# Altium schematic sheet AD9288.SchDoc
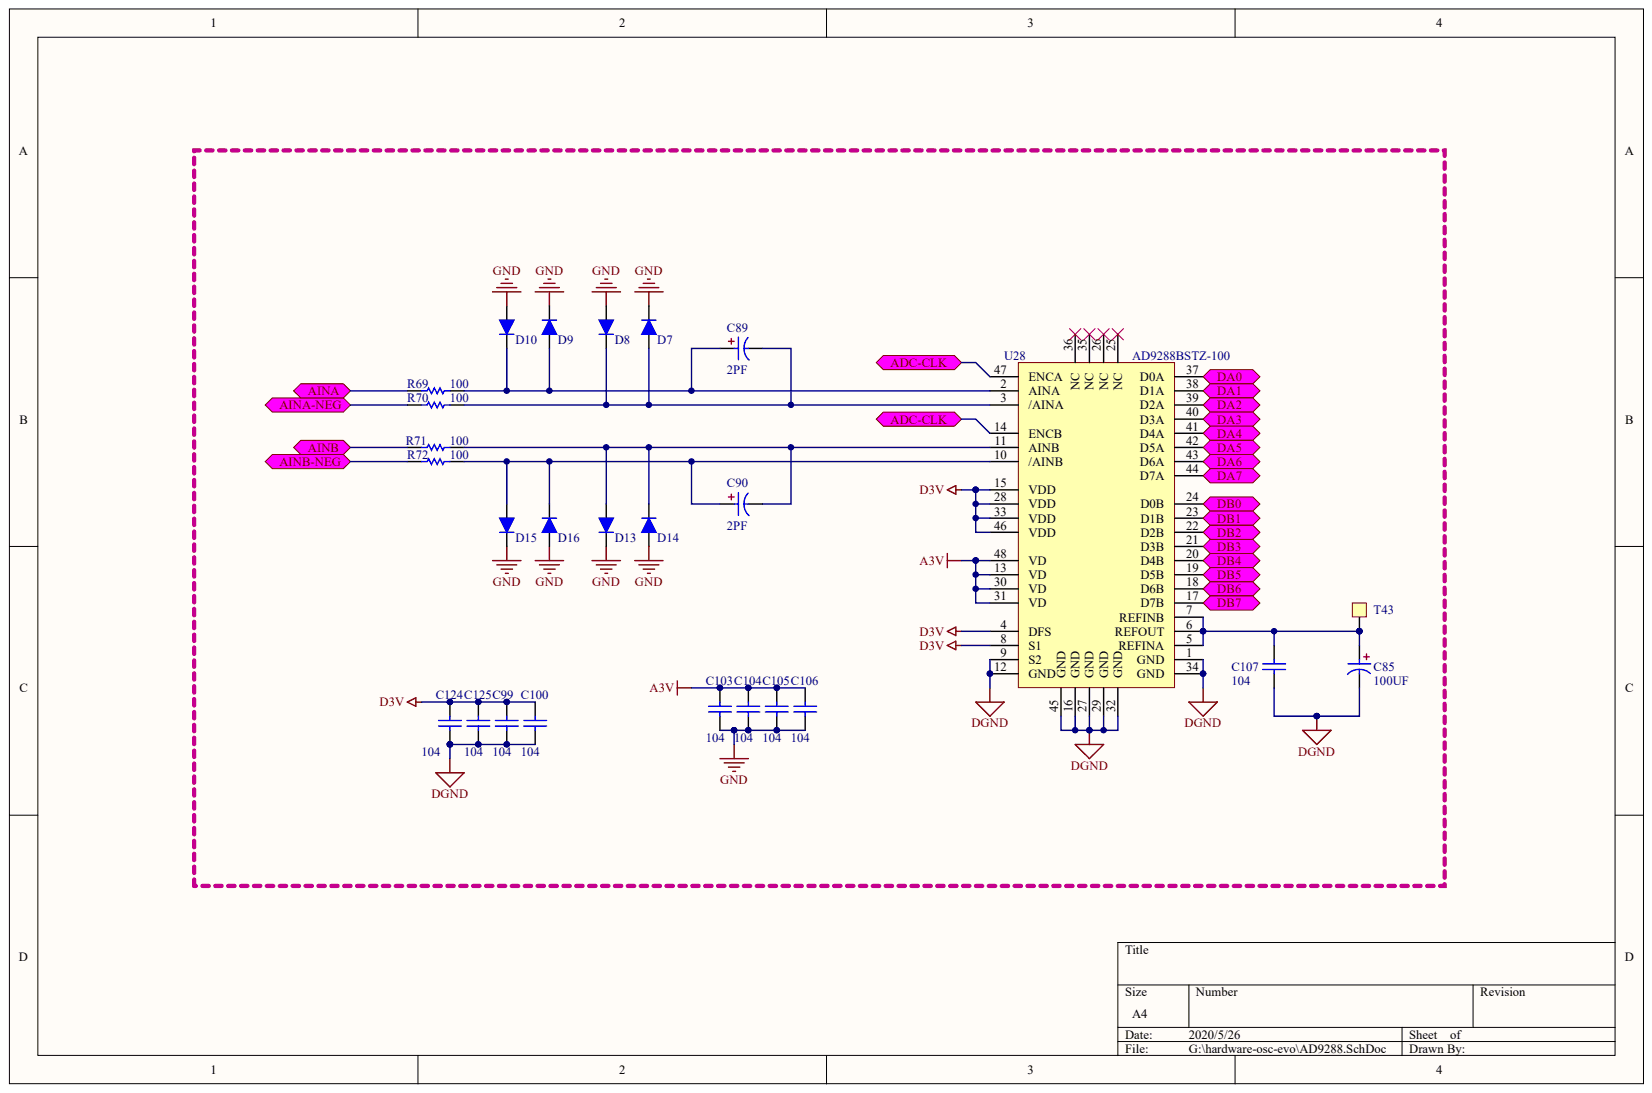
[diagram p0: AD9288.SchDoc]

## Parts
C85  100UF
C89  2PF
C90  2PF
C99  C104  (value not drawn)
C100  C104  (value not drawn)
C103  C104
C104  C104
C105  C104
C106  C104  (value not drawn)
C107  C104  (value not drawn)
C124  C104  (value not drawn)
C125  C104  (value not drawn)
D7  KDS4148U  (value not drawn)
D8  KDS4148U  (value not drawn)
D9  KDS4148U  (value not drawn)
D10  KDS4148U  (value not drawn)
D13  KDS4148U  (value not drawn)
D14  KDS4148U  (value not drawn)
D15  KDS4148U  (value not drawn)
D16  KDS4148U  (value not drawn)
R69  100
R70  100
R71  100
R72  100
T43  TP  (value not drawn)
U28  AD9288BSTZ-100

## Ports
ADC-CLK, AINA, AINA-NEG, AINB, AINB-NEG, DA0, DA1, DA2, DA3, DA4, DA5, DA6, DA7, DB0, DB1, DB2, DB3, DB4, DB5, DB6, DB7

## Nets
A3V: C103.1 C104.1 C105.1 C106.1 U28.13(VD) U28.30(VD) U28.31(VD) U28.48(VD)
D3V: C99.1 C100.1 C124.1 C125.1 U28.4(DFS) U28.8(S1) U28.15(VDD) U28.28(VDD) U28.33(VDD) U28.46(VDD)
DGND: C85.2 C99.2 C100.2 C107.2 C124.2 C125.2 U28.1(GND) U28.9(S2) U28.12(GND) U28.16(GND) U28.27(GND) U28.29(GND) U28.32(GND) U28.34(GND) U28.45(GND)
GND: C103.2 C104.2 C105.2 C106.2 D7.2 D8.1 D9.2 D10.1 D13.2 D14.1 D15.2 D16.1
ADC-CLK: U28.14(ENCB) U28.47(ENCA) ; port ADC-CLK
AINA: R69.2 ; port AINA
AINA-NEG: R70.2 ; port AINA-NEG
AINB: R71.2 ; port AINB
AINB-NEG: R72.2 ; port AINB-NEG
DA0: U28.37(D0A) ; port DA0
DA1: U28.38(D1A) ; port DA1
DA2: U28.39(D2A) ; port DA2
DA3: U28.40(D3A) ; port DA3
DA4: U28.41(D4A) ; port DA4
DA5: U28.42(D5A) ; port DA5
DA6: U28.43(D6A) ; port DA6
DA7: U28.44(D7A) ; port DA7
DB0: U28.24(D0B) ; port DB0
DB1: U28.23(D1B) ; port DB1
DB2: U28.22(D2B) ; port DB2
DB3: U28.21(D3B) ; port DB3
DB4: U28.20(D4B) ; port DB4
DB5: U28.19(D5B) ; port DB5
DB6: U28.18(D6B) ; port DB6
DB7: U28.17(D7B) ; port DB7
NetC85_1: C85.1 C107.1 T43.1 U28.5(REFINA) U28.6(REFOUT) U28.7(REFINB)
NetC89_1: C89.1 D9.1 D10.2 R69.1 U28.2(AINA)
NetC89_2: C89.2 D7.1 D8.2 R70.1 U28.3(/AINA)
NetC90_1: C90.1 D15.1 D16.2 R72.1 U28.10(/AINB)
NetC90_2: C90.2 D13.1 D14.2 R71.1 U28.11(AINB)

Not connected: U28 25(NC) 26(NC) 35(NC) 36(NC)

## Library data of the parts
C85 C89 C90 C99 C100 C103-C107 C124 C125 D7-D10 D13-D16 R69-R72 T43 U28 = (no symbol name)
  C85: : 100UF
  C89 C90: : 2PF
  C99 C100 C103-C107 C124 C125: : 104
  R69-R72: : 100
